# Altium schematic sheet LoRa.SchDoc
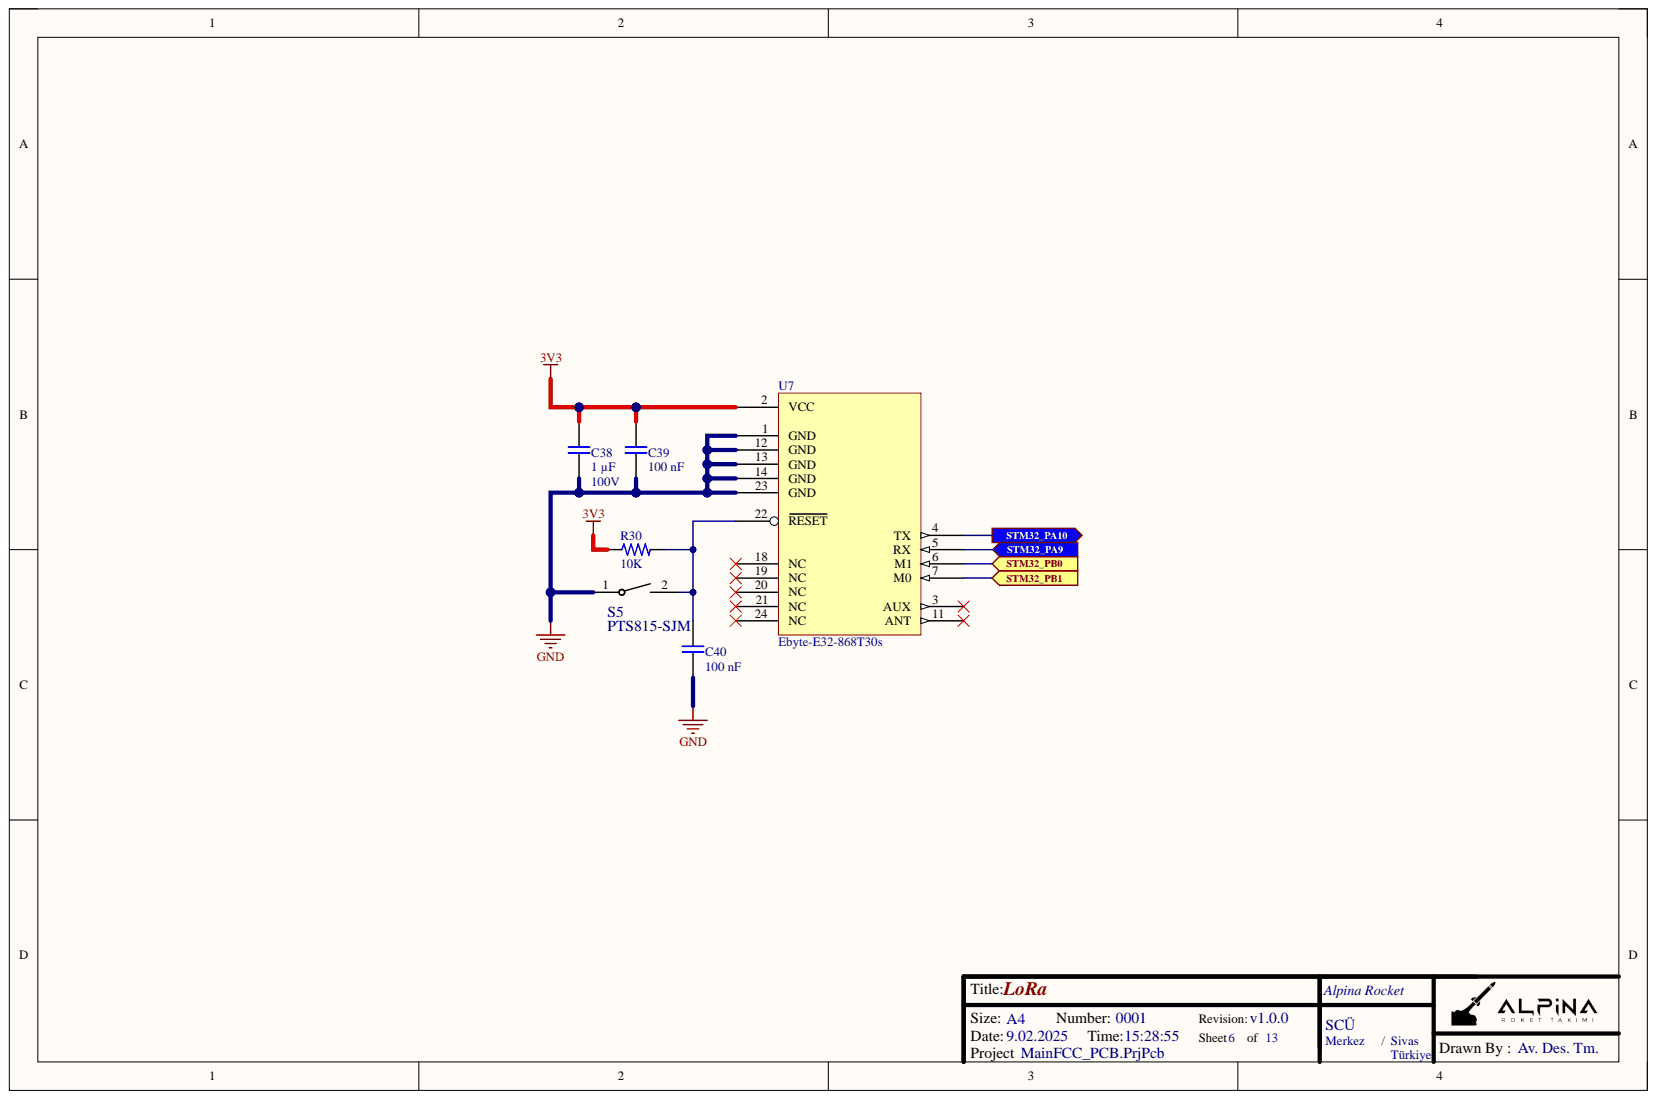
[diagram p0: LoRa.SchDoc]

## Parts
C38  1 µF  Voltage Rating: 100V  [MergenElektronik_Capacitors_Ceramics_SMDs_1206_M_NS]
C39  100 nF  Voltage Rating: 100V  [MergenElektronik_Capacitors_Ceramics_SMDs_0603_M_NS]
C40  100 nF  [MergenElektronik_Capacitors_Ceramics_SMDs_0603_M_NS]
R30  10K  [MergenElektronik_Resistors_ChipResistors_0603_M_NS]
S5  PTS815-SJM  [MergenElektronik_Switches_TactileSwitches_PTS815]
U7  Ebyte-E32-868T30s  [MergenElektronik_Modules_LoRas_Ebyte-E32-xxxxT30x]

## Ports
STM32_PA9, STM32_PA10, STM32_PB0, STM32_PB1

## Nets
3V3: C38.1 C39.1 R30.1 U7.2(VCC)
GND: C38.2 C39.2 C40.2 S5.1 U7.1(GND) U7.12(GND) U7.13(GND) U7.14(GND) U7.23(GND)
STM32_PA9: U7.5(RX) ; port STM32_PA9
STM32_PA10: U7.4(TX) ; port STM32_PA10
STM32_PB0: U7.6(M1) ; port STM32_PB0
STM32_PB1: U7.7(M0) ; port STM32_PB1
NetC40_1: C40.1 R30.2 S5.2 U7.22(RESET)

Not connected: U7 3(AUX) 11(ANT) 18(NC) 19(NC) 20(NC) 21(NC) 24(NC)

## Library data of the parts
C38 = MergenElektronik_Capacitors_Ceramic_SMDs_1µF_100V: Mergen Elektronik - Capacitors - Ceramics - SMDs - 1206 - 1 µF - 100V; Manufacturer: Samsung Electro-Mechanics; Manufacturer Number: CL31B105KCHNNNE; Model: Ceramic Capacitors; Tolerance: ±10%; Component Kind: Standard; Digi-Key Number: 1276-1838-1-ND; Library Name: MergenElektronik_Capacitors_Ceramics_SMDs.SchLib; Mounting Type: Surface Mount; Mouser Number: 187-CL31B105KCHNNNE; Package: 1206(3216 Metric); Supplier 1: Digikey; Supplier 2: Mouser; Supplier Part Number 1: 1276-1838-1-ND; Supplier Part Number 2: 187-CL31B105KCHNNNE; Temperature: -55°C up to +125°C; Value: 1 µF; Özdisan Number: CL31B105KCHNNNE
C39 C40 = MergenElektronik_Capacitors_Ceramic_SMDs_100nF_100V: Mergen Elektronik - Capacitors - Ceramics - SMDs - 0603 - 100 nF - 100V; Manufacturer: Samsung Electro-Mechanics; Manufacturer Number: CL10B104KC8NNNC; Model: Ceramic Capacitors; Tolerance: ±10%; Component Kind: Standard; Digi-Key Number: 1276-6807-1-ND; Farnell Number: 3013412; Library Name: MergenElektronik_Capacitors_Ceramics_SMDs.SchLib; Mounting Type: Surface Mount; Mouser Number: 187-CL10B104KC8NNNC; Package: 0603(1608 Metric); Supplier 1: Digikey; Supplier 2: Mouser; Supplier Part Number 1: 1276-6807-1-ND; Supplier Part Number 2: 187-CL10B104KC8NNNC; Temperature: -55°C up to +125°C; Value: 100 nF; Özdisan Number: CL10B104KC8NNNC
  C40: Voltage Rating: 100V
R30 = MergenElektronik_Resistors_ChipResistors_0603_10K: Mergen Elektronik - Resistors - Chip Resistors - 0603 - 10K; Manufacturer: Stackpole Electronics Inc; Manufacturer Number: RMCF0603JT10K0; Power: 1/10W; Tolerance: ±5%; Value: Chip Resistor - 0603 - 10K; Component Kind: Standard; Digi-Key Number: RMCF0603JT10K0CT-ND; Library Name: MergenElektronik_Resistors_ChipResistors.IntLib; Model: Chip Resistor; Mounting Type: Surface Mount; Package: 0603(1608); Supplier 1: Digi-Key; Supplier Part Number 1: RMCF0603JT10K0-ND; Temperature: -55°C up to +155°C - X8R
S5 = MergenElektronik_Switches_TactileSwitches_PTS815-SJM: Mergen Elektronik - Switches - Tactile  Switches; Manufacturer: C&K; Manufacturer Number: PTS815 SJM 250 SMTR LFS; Current Rating: 50mA; Voltage Rating: 12VDC; Component Kind: Standard; Digi-Key Number: CKN12215-1-ND; Farnell Number: 3780619; Library Name: MergenElektronik_Switches_TactileSwitches.SchLib; Model: Tactile Switches; Mounting Type: Surface Mount; Mouser Number: 611-PTS815SJM250SMTR; Package: PTS815; Supplier 1: Mouser; Supplier 2: Digi-Key; Supplier Part Number 1: 611-PTS815SJM250SMTR; Supplier Part Number 2: CKN12215-6-ND; Temperature: -20°C up to +70°C; Value: PTS815-SJM
U7 = MergenElektronik_Modules_LoRas_Ebyte-E32-868T30s: Mergen Elektronik - Modules - LoRas - Ebyte-E32-868T30s; Manufacturer: Ebyte; Distance: 8 km; Frequency: 862-893MHz; Power: 30dBm; IC: SX1276; Interface: UART; Component Kind: Standard; Library Name: MergenElektronik_Modules_LoRas.SchLib; Manufacturer Number: E32-868T30s
